# Altium schematic sheet 2_DisplayController_rA1.SchDoc
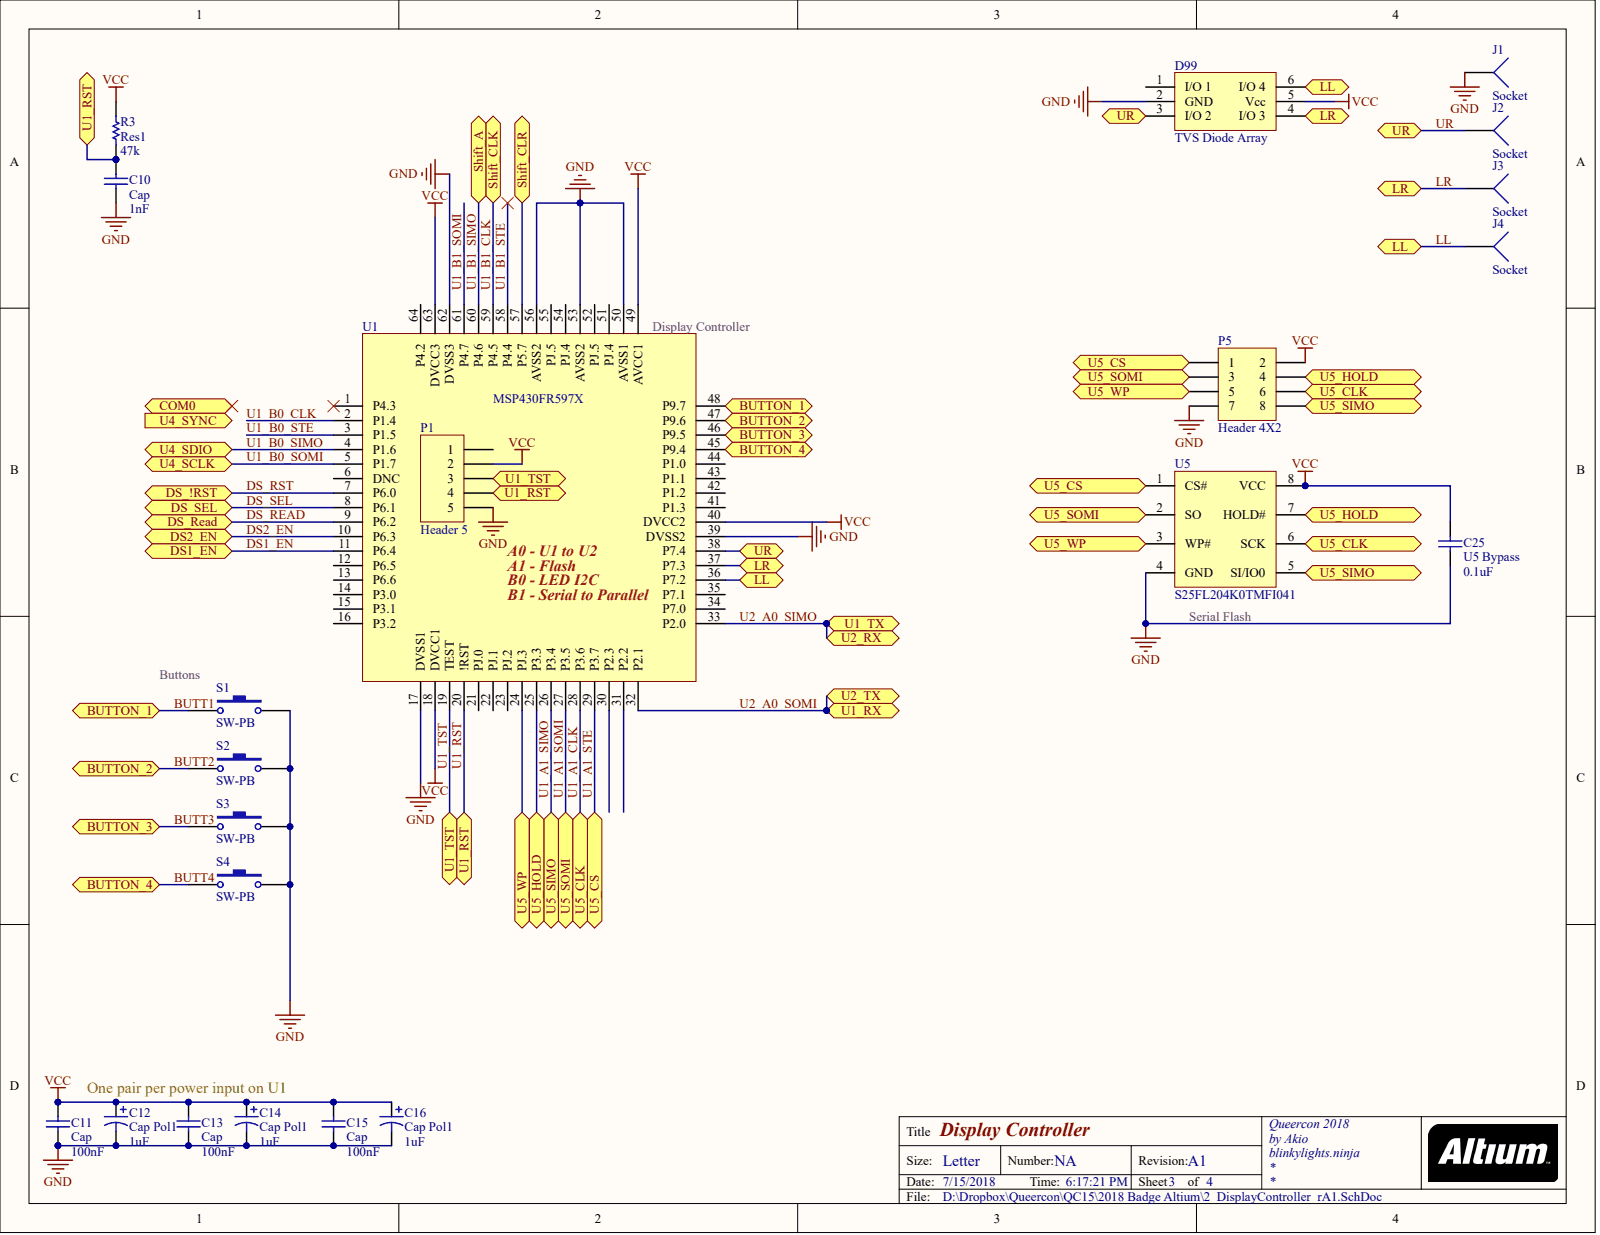
[diagram p0: 2_DisplayController_rA1.SchDoc]

## Parts
C10  Cap  value 1nF
C11  Cap  value 100nF
C12  Cap Pol1  value 1uF
C13  Cap  value 100nF
C14  Cap Pol1  value 1uF
C15  Cap  value 100nF
C16  Cap Pol1  value 1uF
C25  U5 Bypass  value 0.1uF
D99  TVS Diode Array
J1  Socket
J2  Socket
J3  Socket
J4  Socket
P1  Header 5
P5  Header 4X2
R3  Res1  value 47k
S1  SW-PB
S2  SW-PB
S3  SW-PB
S4  SW-PB
U1  MSP430FR597X
U5  S25FL204K0TMFI041

## Ports
BUTTON_1, BUTTON_2, BUTTON_3, BUTTON_4, COM0, DS1_EN, DS2_EN, DS_!RST, DS_READ, DS_SEL, LL, LR, SHIFT_A, SHIFT_CLK, SHIFT_CLR, U1_RST, U1_RX, U1_TST, U1_TX, U2_RX, U2_TX, U4_SCLK, U4_SDIO, U4_SYNC, U5_CLK, U5_CS, U5_HOLD, U5_SIMO, U5_SOMI, U5_WP, UR

## Nets
GND: C10.1 C11.1 C12.2 C13.1 C14.2 C15.1 C16.2 C25.1 D99.2(GND) J1.1 P1.5 P5.7 S1.2 S2.2 S3.2 S4.2 U1.17(DVSS1) U1.39(DVSS2) U1.50(AVSS1) U1.53(AVSS2) U1.56(AVSS2) U1.62(DVSS3) U5.4(GND)
VCC: C11.2 C12.1 C13.2 C14.1 C15.2 C16.1 C25.2 D99.5(Vcc) P1.2 P5.2 R3.2 U1.18(DVCC1) U1.40(DVCC2) U1.49(AVCC1) U1.63(DVCC3) U5.8(VCC)
BUTT1: S1.1 U1.48(P9.7) ; port BUTTON_1
BUTT2: S2.1 U1.47(P9.6) ; port BUTTON_2
BUTT3: S3.1 U1.46(P9.5) ; port BUTTON_3
BUTT4: S4.1 U1.45(P9.4) ; port BUTTON_4
DS1_EN: U1.11(P6.4) ; port DS1_EN
DS2_EN: U1.10(P6.3) ; port DS2_EN
DS_READ: U1.9(P6.2) ; port DS_READ
DS_RST: U1.7(P6.0) ; port DS_!RST
DS_SEL: U1.8(P6.1) ; port DS_SEL
LL: D99.6(I/O_4) J4.1 U1.36(P7.2) ; port LL
LR: D99.4(I/O_3) J3.1 U1.37(P7.3) ; port LR
U1_A1_CLK: P5.6 U1.28(P3.6) U5.6(SCK) ; port U5_CLK
U1_A1_SIMO: P5.8 U1.26(P3.4) U5.5(SI/IO0) ; port U5_SIMO
U1_A1_SOMI: P5.3 U1.27(P3.5) U5.2(SO) ; port U5_SOMI
U1_A1_STE: P5.1 U1.29(P3.7) U5.1(CS#) ; port U5_CS
U1_B0_CLK: U1.2(P1.4)
U1_B0_SIMO: U1.4(P1.6) ; port U4_SDIO
U1_B0_SOMI: U1.5(P1.7) ; port U4_SCLK
U1_B0_STE: U1.3(P1.5)
U1_B1_CLK: U1.59(P4.5) ; port SHIFT_CLK
U1_B1_SIMO: U1.60(P4.6) ; port SHIFT_A
U1_B1_SOMI: U1.61(P4.7)
U1_B1_STE: U1.58(P4.4)
U1_RST: C10.2 P1.4 R3.1 U1.20(!RST) ; port U1_RST
U1_TST: P1.3 U1.19(TEST) ; port U1_TST
U2_A0_SIMO: U1.33(P2.0) ; port U1_TX, port U2_RX
U2_A0_SOMI: U1.32(P2.1) ; port U1_RX, port U2_TX
U5_HOLD: P5.4 U1.25(P3.3) U5.7(HOLD#) ; port U5_HOLD
U5_WP: P5.5 U1.24(PJ.3) U5.3(WP#) ; port U5_WP
UR: D99.3(I/O_2) J2.1 U1.38(P7.4) ; port UR
NetU1_57: U1.57(P5.7) ; port SHIFT_CLR
N00074: ; port U4_SYNC
N00075: ; port COM0

Not connected: D99 1(I/O_1); P1 1; U1 1(P4.3) 6(DNC) 12(P6.5) 13(P6.6) 14(P3.0) 15(P3.1) 16(P3.2) 21(PJ.0) 22(PJ.1) 23(PJ.2) 30(P2.3) 31(P2.2) 34(P7.0) 35(P7.1) 41(P1.3) 42(P1.2) 43(P1.1) 44(P1.0) 51(PJ.4) 52(PJ.5) 54(PJ.4) 55(PJ.5) 64(P4.2)

## Library data of the parts
C10-C16 C25 D99 J1-J4 P1 P5 R3 S1-S4 U1 U5 = (no symbol name)
  C10 C11 C13 C15 C25: Published: 8-Jun-2000; LatestRevisionDate: 17-Jul-2002; LatestRevisionNote: Re-released for DXP Platform.; PackageReference: RAD-0.3; PackageDescription: Radial Cap, Thru-Hole; 2 Leads; 0.3 in Pin Spacing
  C12 C14 C16: Published: 8-Jun-2000; LatestRevisionDate: 17-Jul-2002; LatestRevisionNote: Re-released for DXP Platform.; PackageReference: RB7.6-15; PackageDescription: Polarized Capacitor (Radial); 2 Leads
  J1-J4 P1 P5: LatestRevisionDate: 17-Jul-2002; LatestRevisionNote: Re-released for DXP Platform.
  R3: Published: 8-Jun-2000; LatestRevisionDate: 17-Jul-2002; LatestRevisionNote: Re-released for DXP Platform.; PackageReference: AXIAL-0.3; PackageDescription: Axial Device, Thru-Hole; 2 Leads; 0.3 in Pin Spacing
  S1-S4: Published: 24-Mar-1999; LatestRevisionDate: 17-Jul-2002; LatestRevisionNote: Re-released for DXP Platform.; PackageReference: SPST-2; PackageDescription: Switch; 2 Leads
  C10-C16 C25 J1-J4 P1 P5 R3 S1-S4: Publisher: Altium Limited
